# Altium schematic sheet CITest Board_v3.1_B.SchDoc
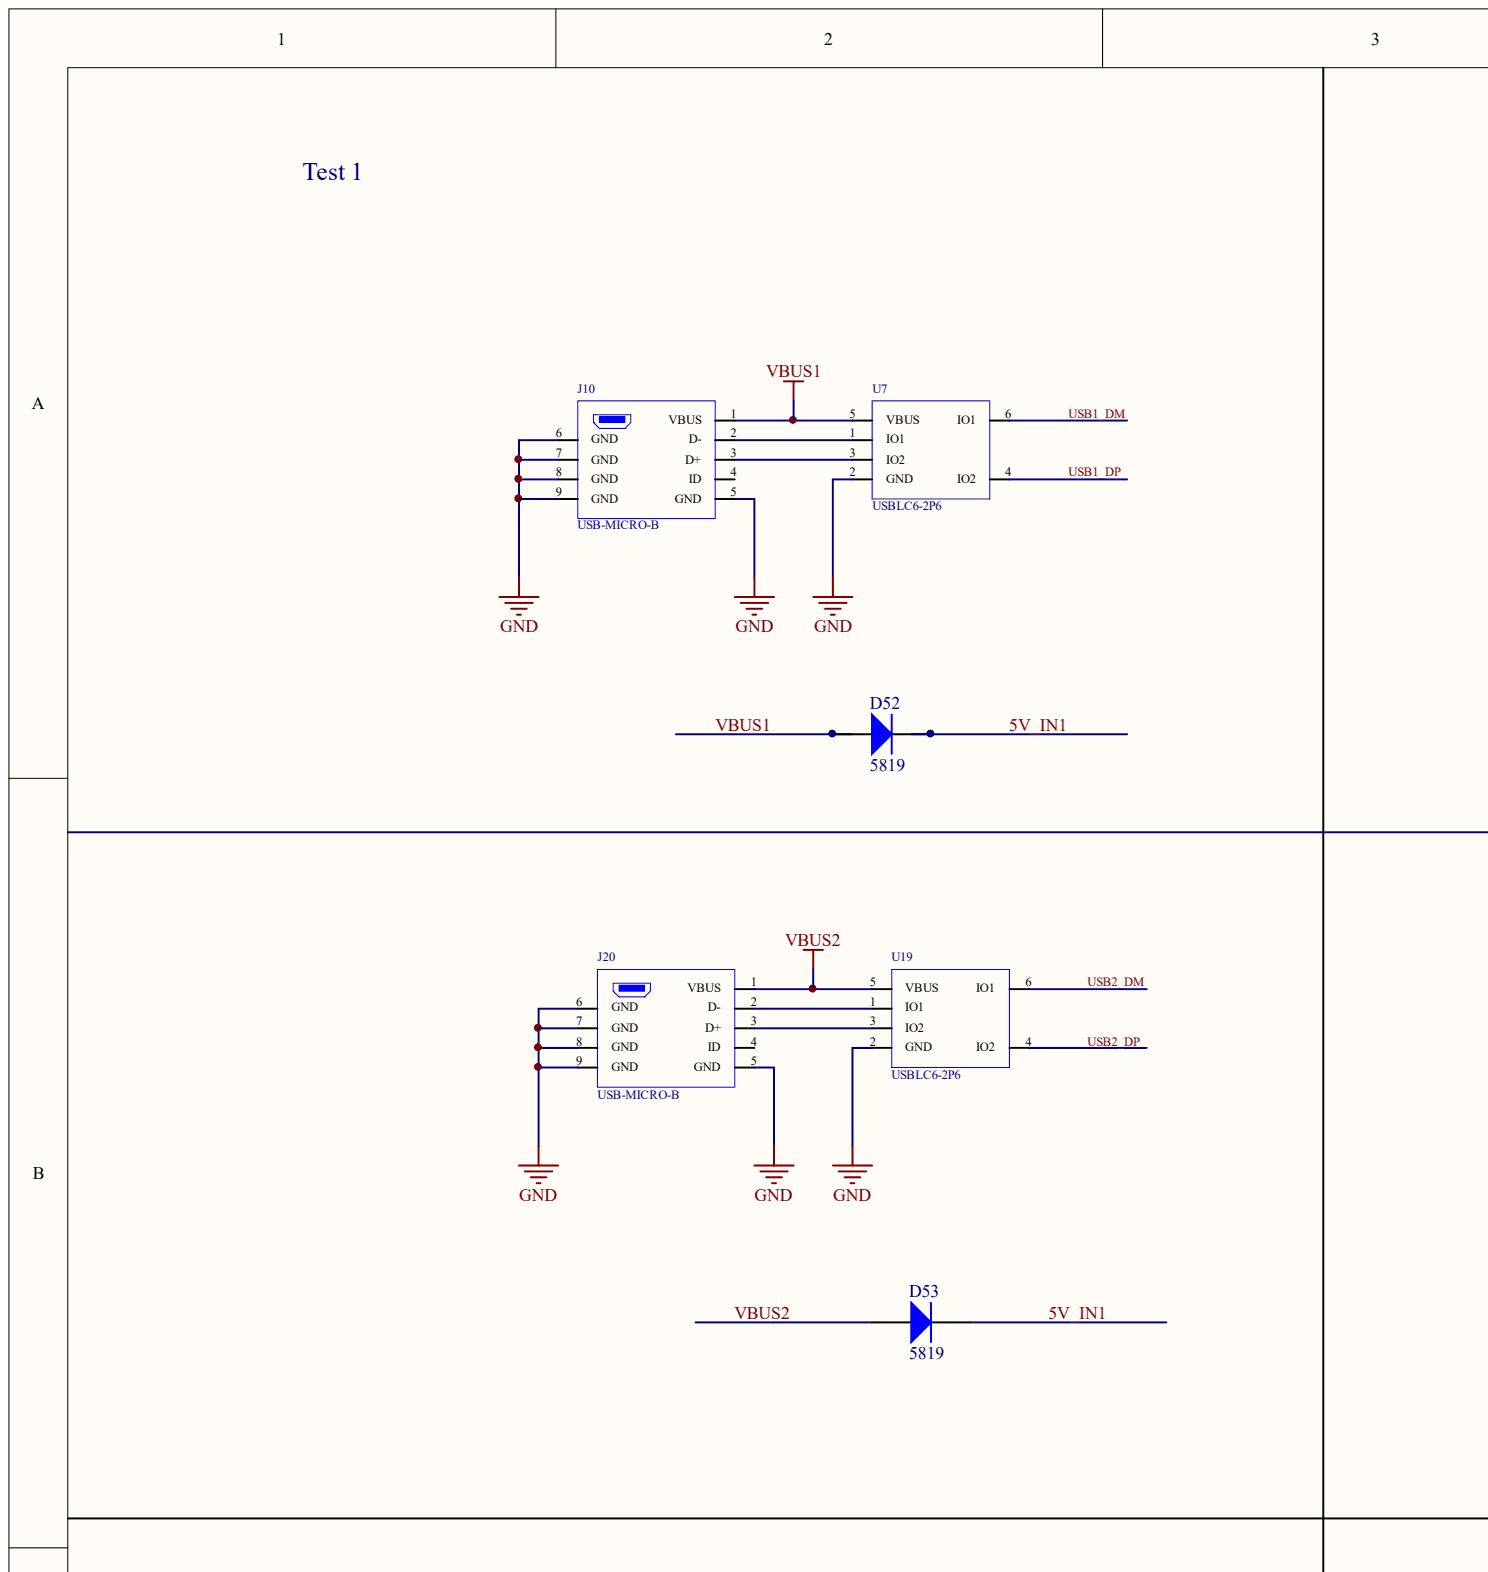
[diagram p0: CITest Board_v3.1_B.SchDoc, top left]
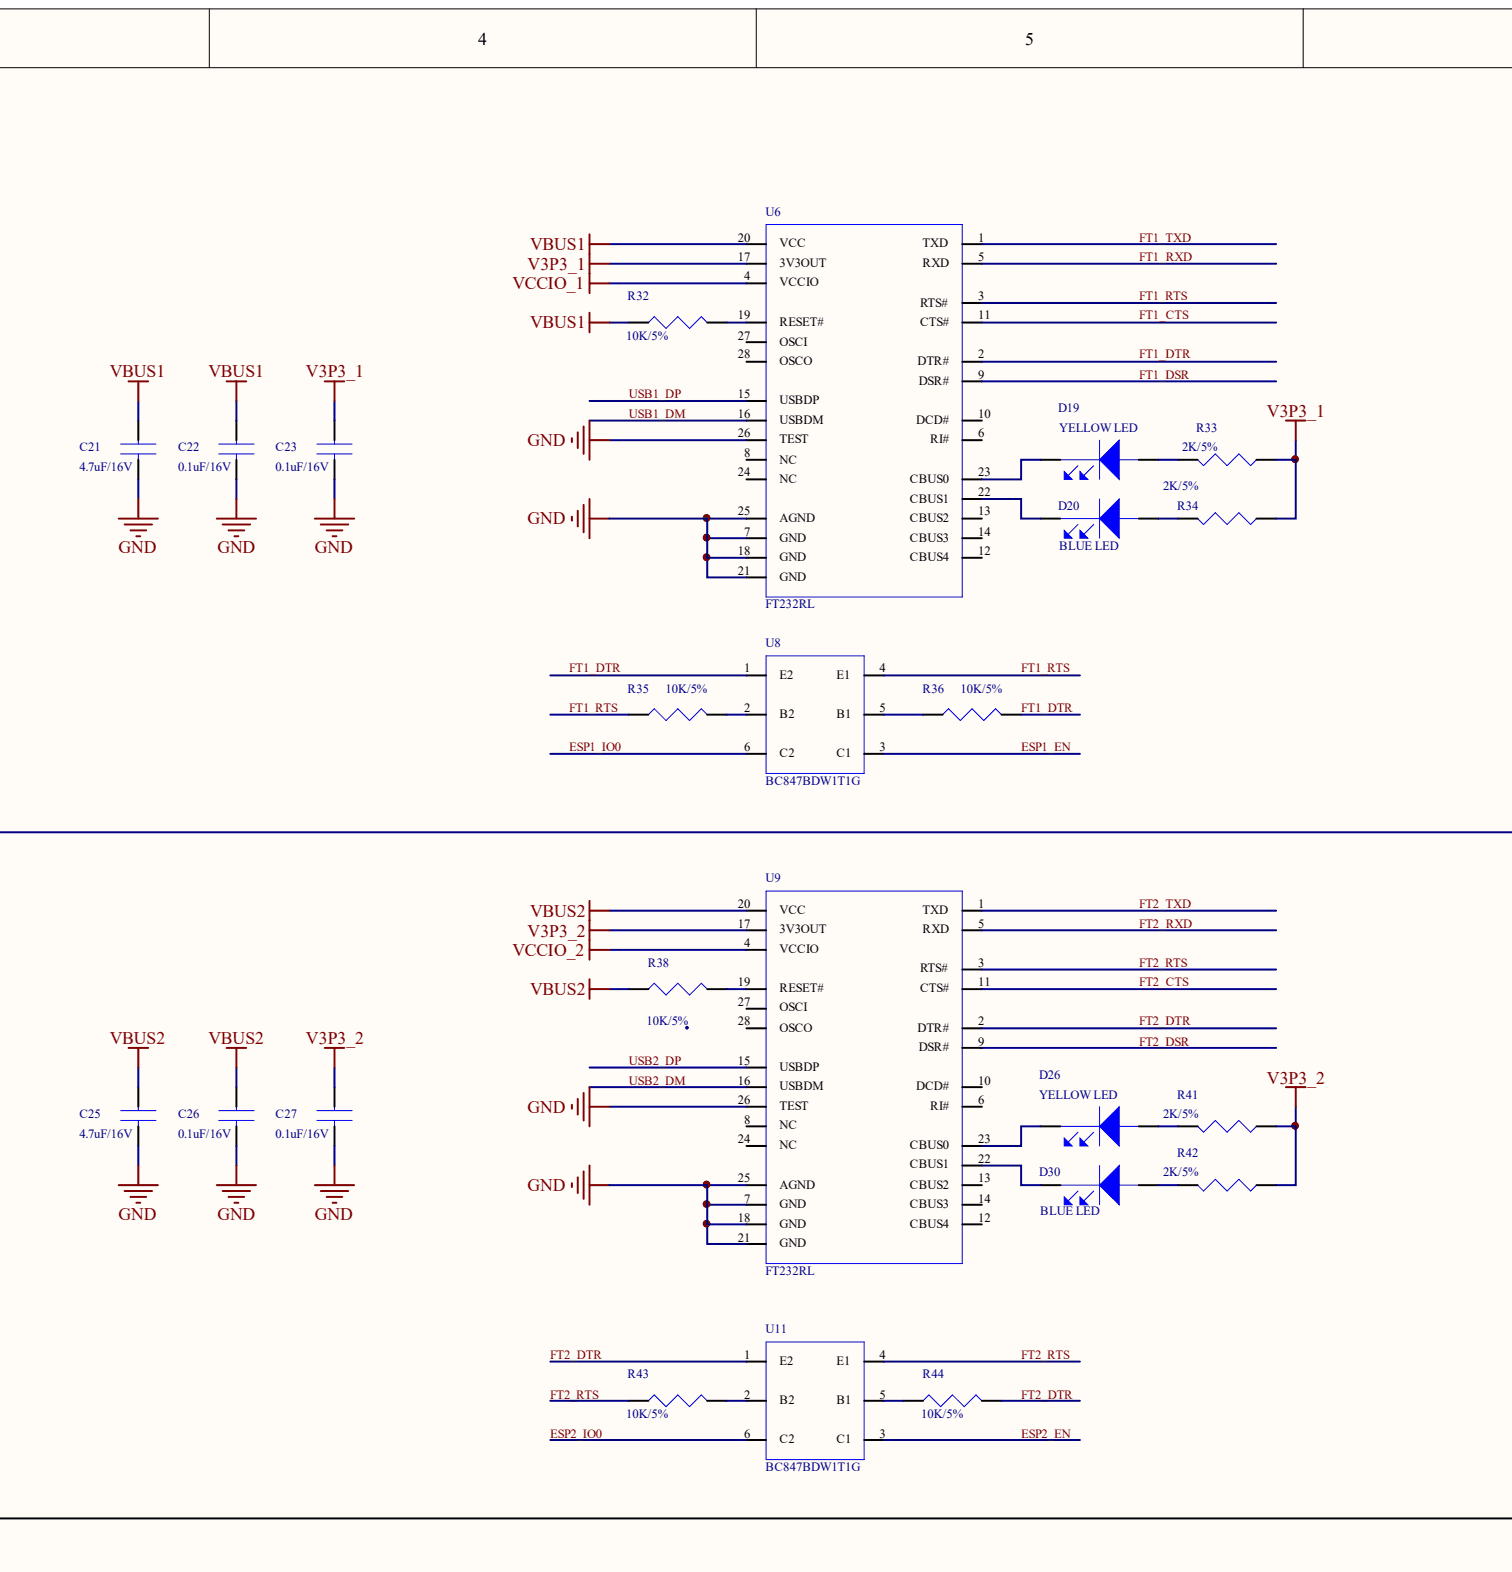
[diagram p1: CITest Board_v3.1_B.SchDoc, top centre]
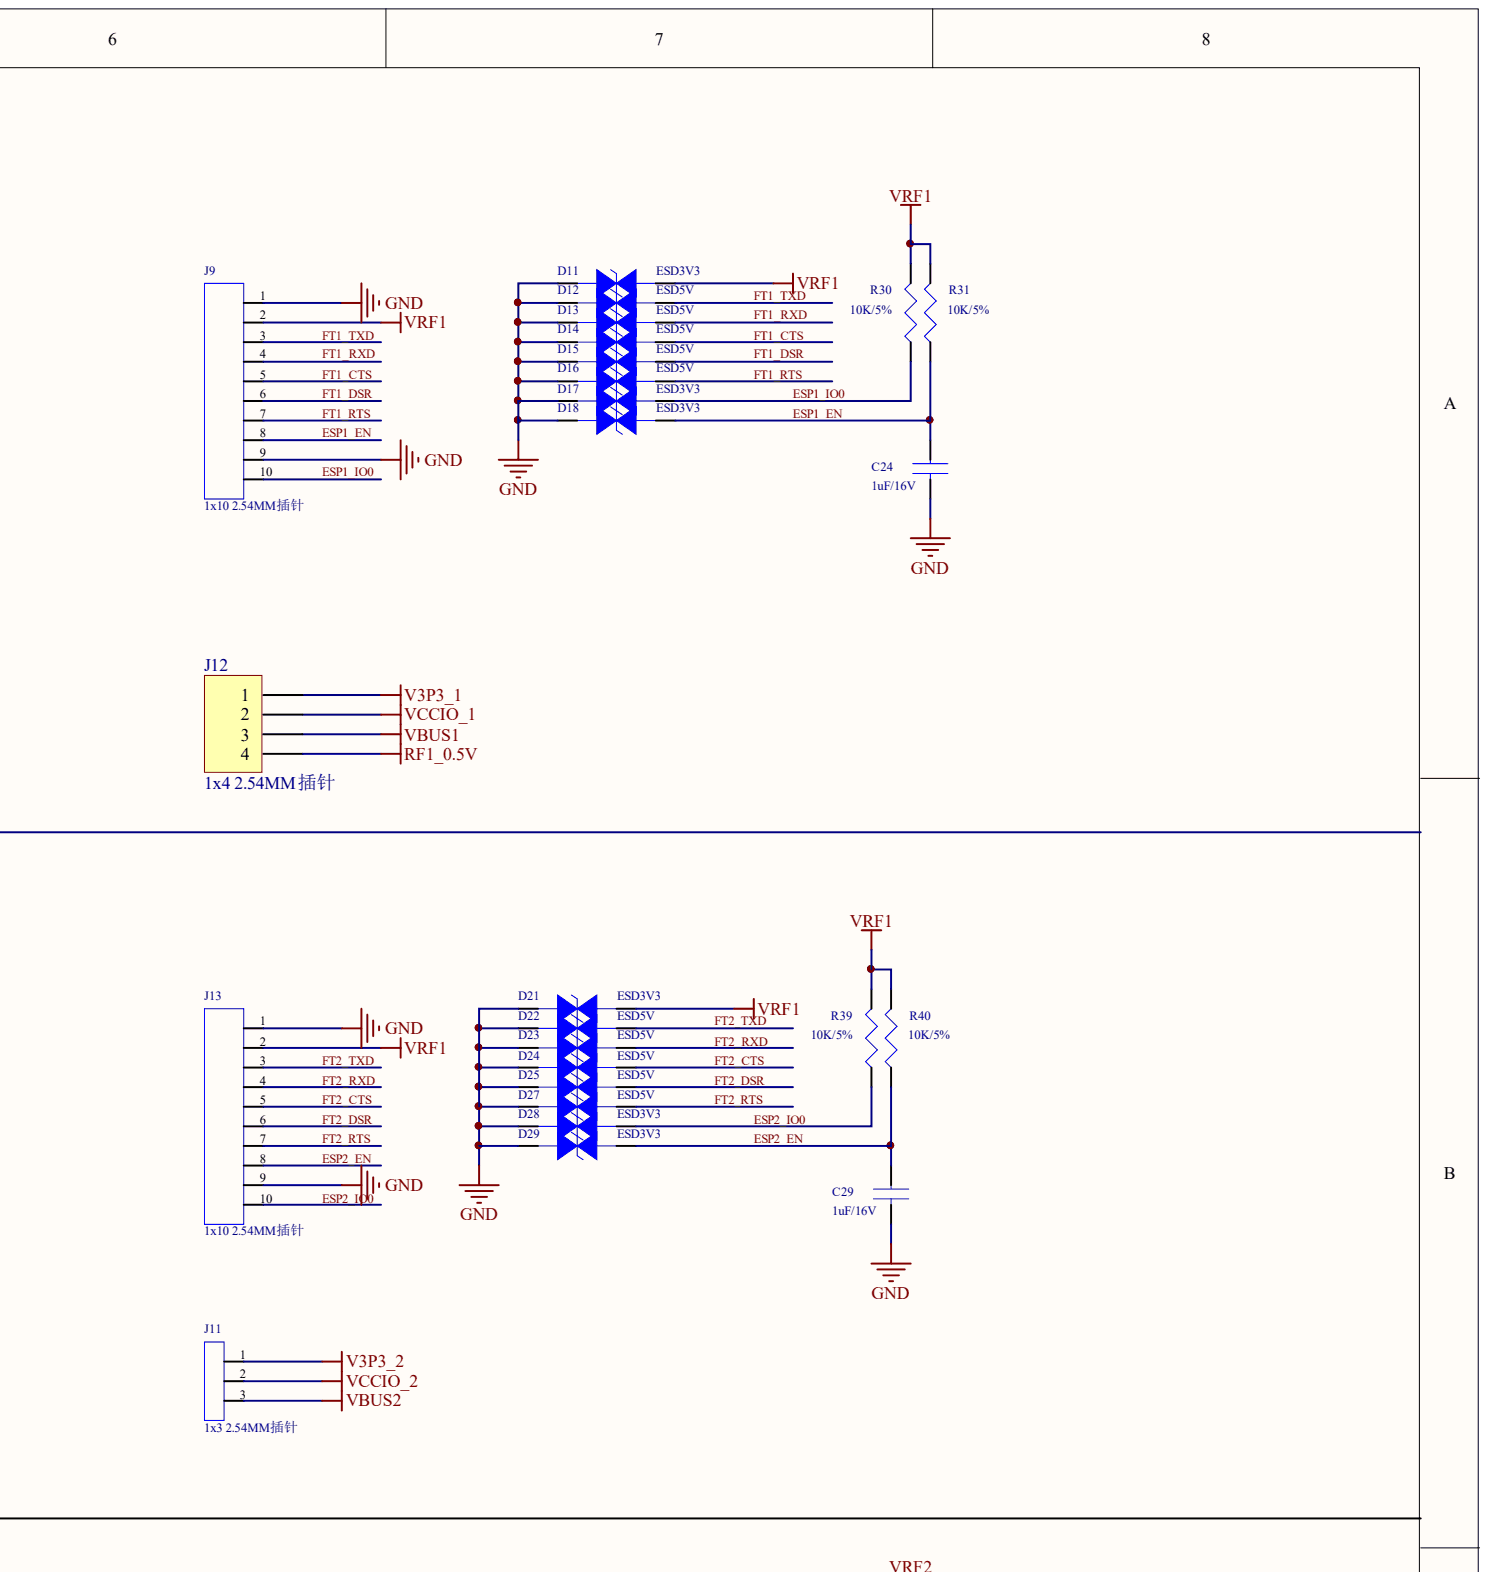
[diagram p2: CITest Board_v3.1_B.SchDoc, top right]
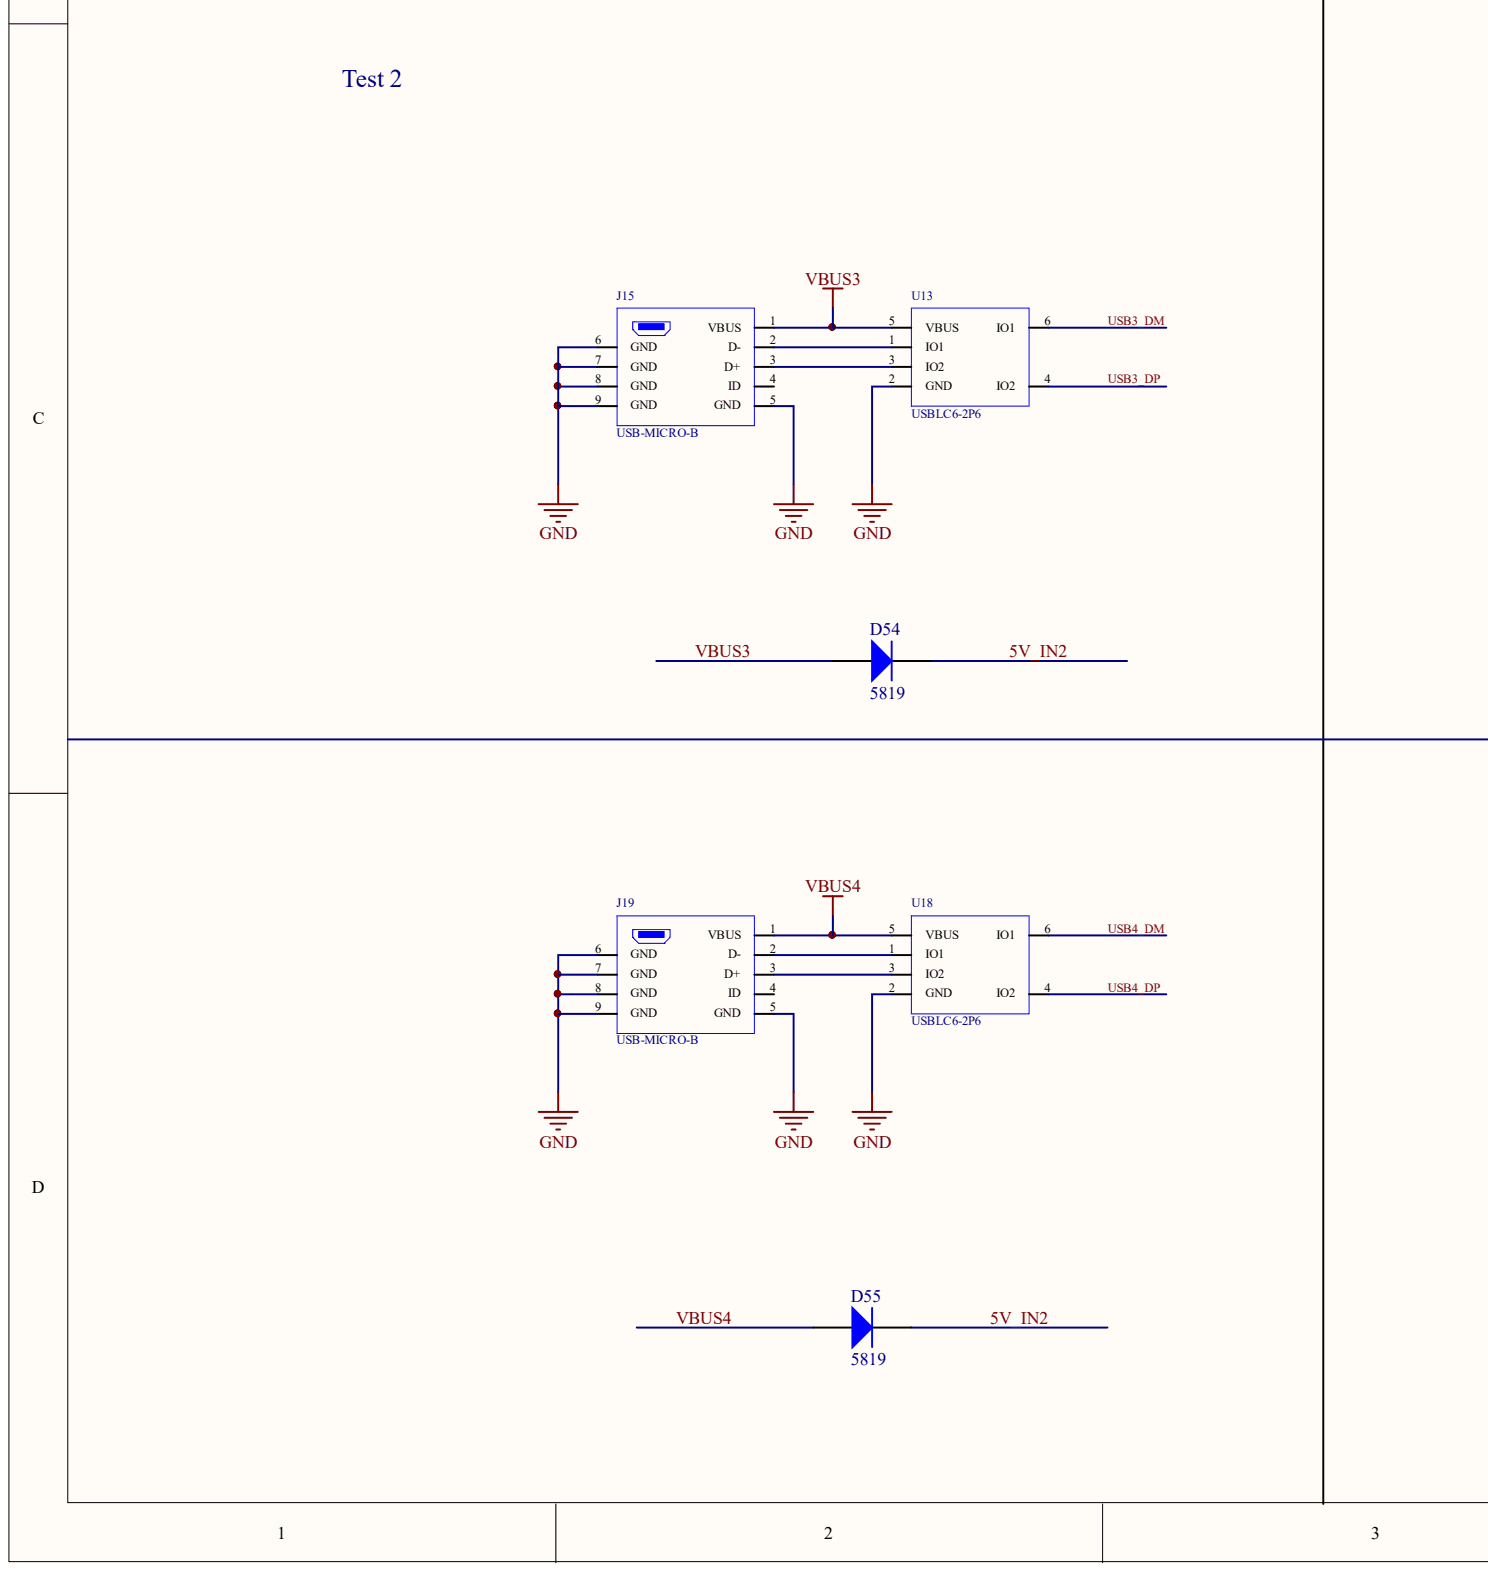
[diagram p3: CITest Board_v3.1_B.SchDoc, bottom left]
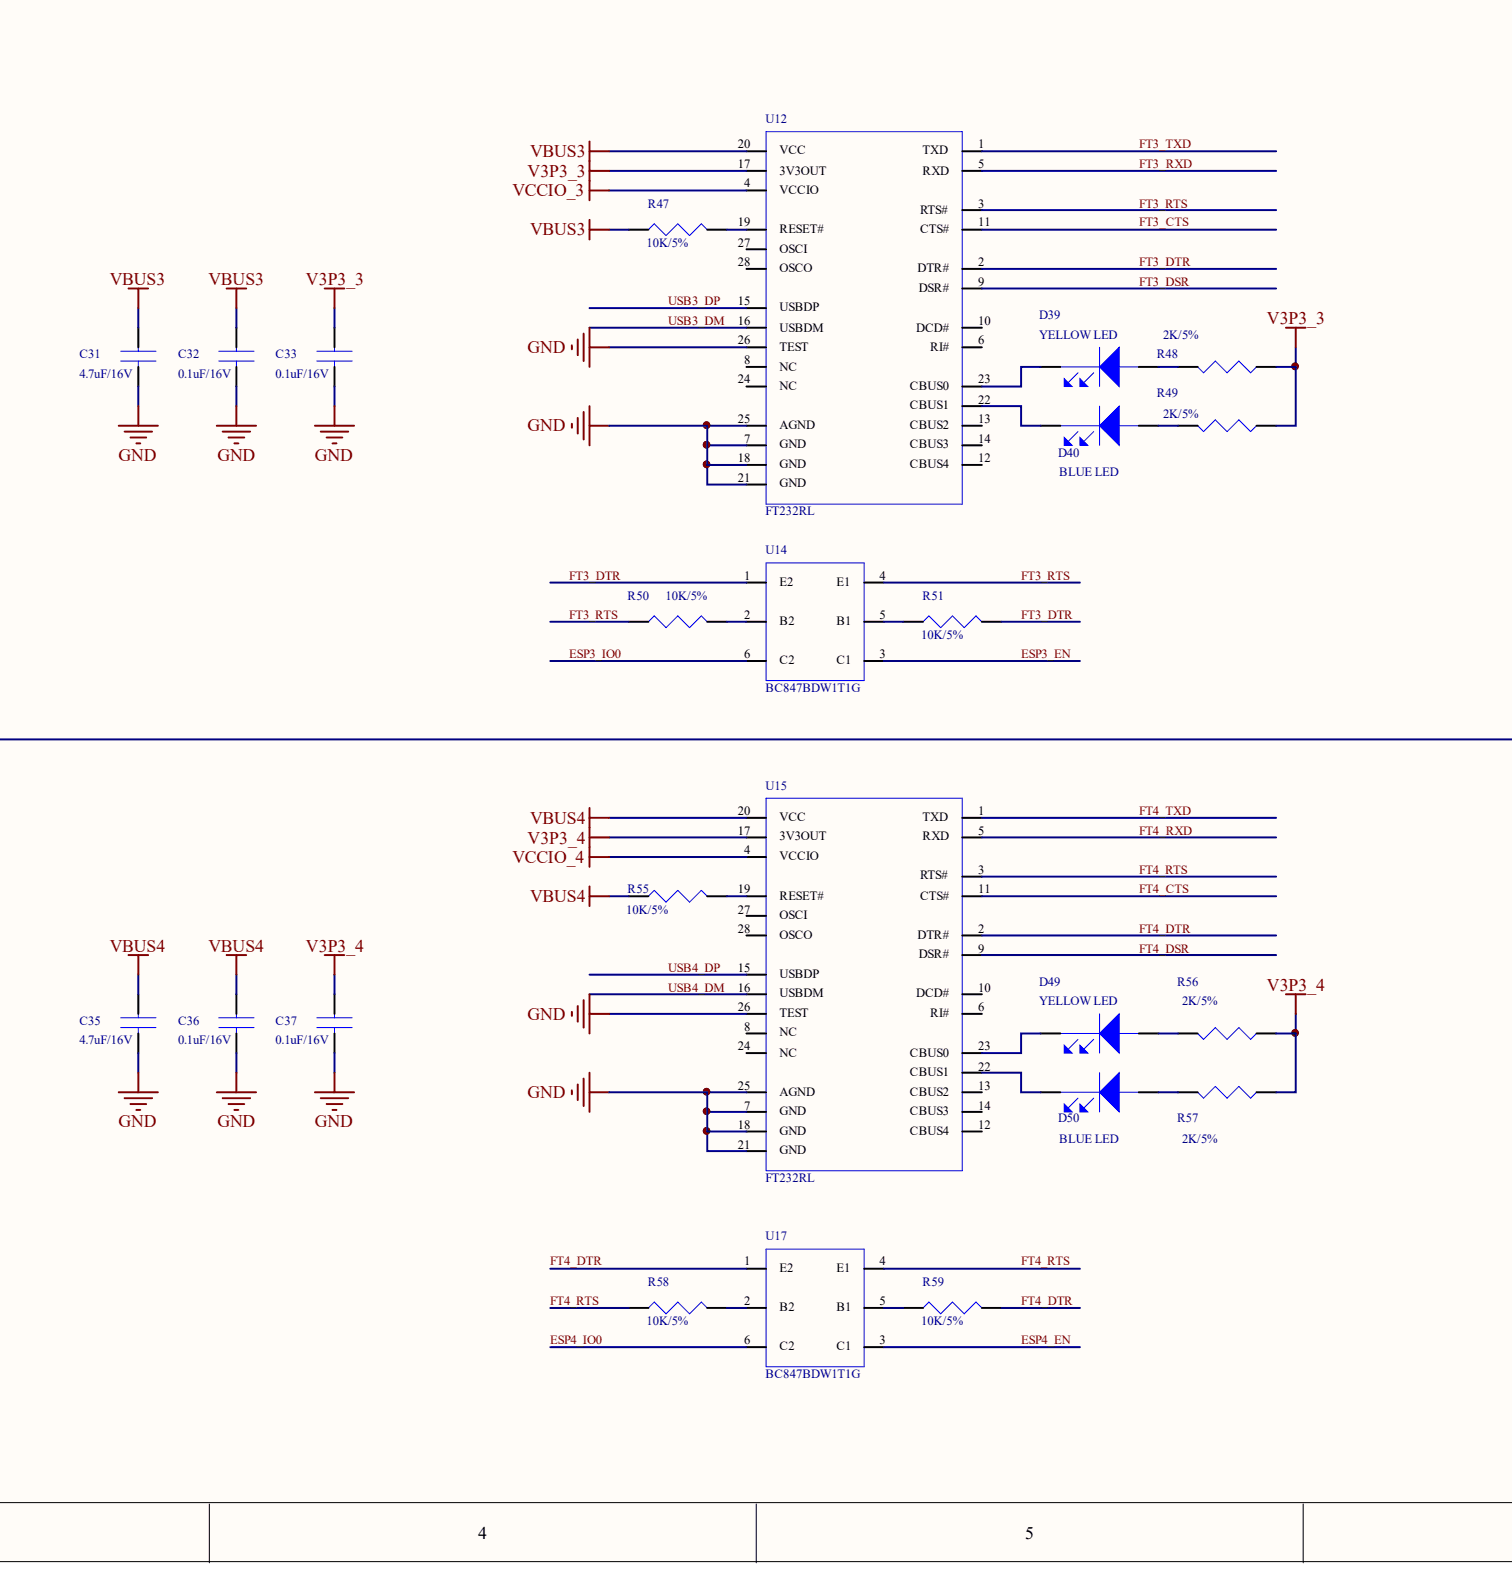
[diagram p4: CITest Board_v3.1_B.SchDoc, bottom centre]
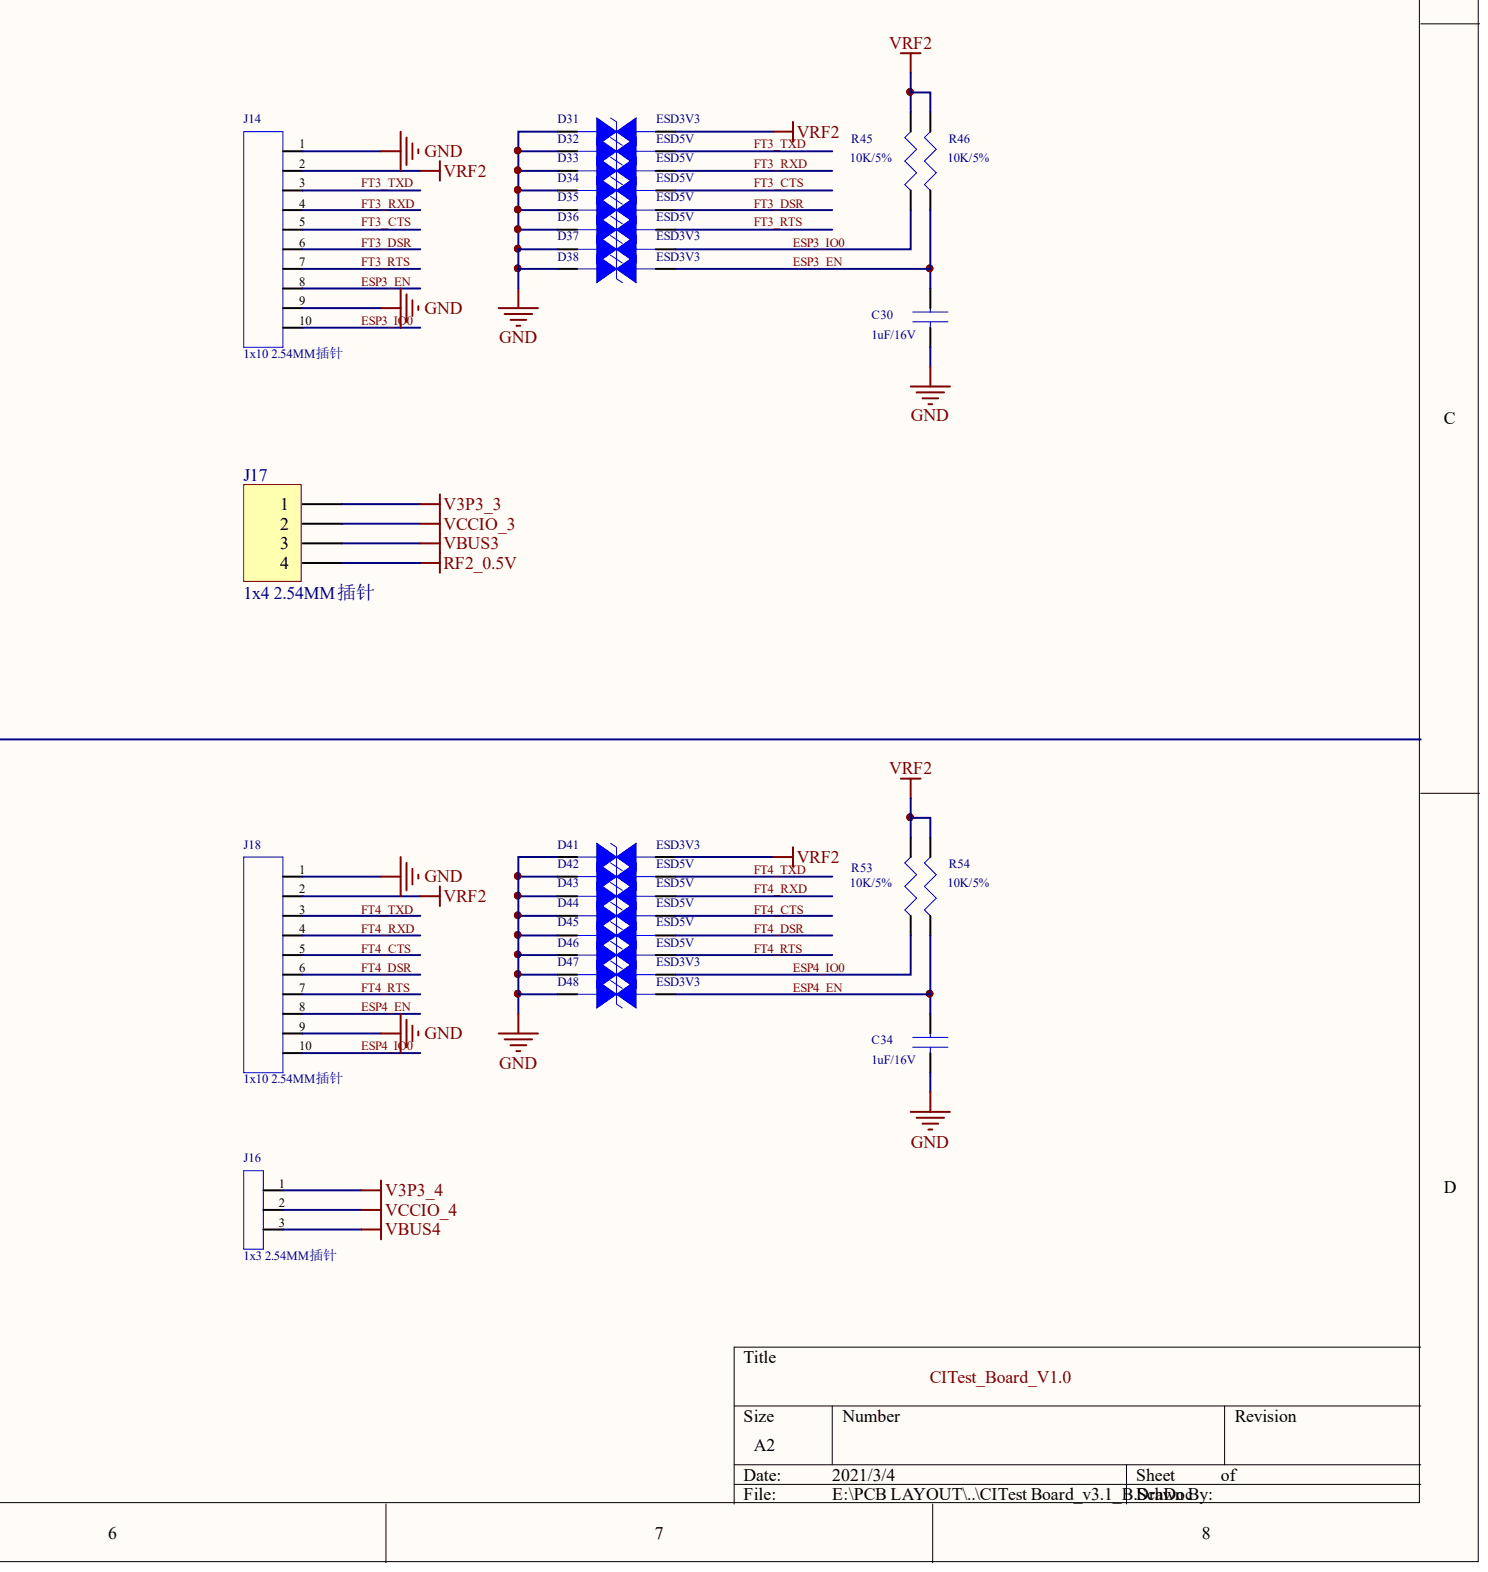
[diagram p5: CITest Board_v3.1_B.SchDoc, bottom right]

## Parts
C21  4.7uF/16V
C22  0.1uF/16V
C23  0.1uF/16V
C24  1uF/16V
C25  4.7uF/16V
C26  0.1uF/16V
C27  0.1uF/16V
C29  1uF/16V
C30  1uF/16V
C31  4.7uF/16V
C32  0.1uF/16V
C33  0.1uF/16V
C34  1uF/16V
C35  4.7uF/16V
C36  0.1uF/16V
C37  0.1uF/16V
D11  ESD3V3
D12  ESD5V
D13  ESD5V
D14  ESD5V
D15  ESD5V
D16  ESD5V
D17  ESD3V3
D18  ESD3V3
D19  YELLOW LED
D20  BLUE LED
D21  ESD3V3
D22  ESD5V
D23  ESD5V
D24  ESD5V
D25  ESD5V
D26  YELLOW LED
D27  ESD3V3
D28  ESD3V3
D29
D30  BLUE LED
D31  ESD3V3
D32  ESD5V
D33  ESD5V
D34  ESD5V
D35  ESD5V
D36  ESD3V3
D37  ESD3V3
D38  ESD5V
D39  YELLOW LED
D40  BLUE LED
D41  ESD5V
D42  ESD5V
D43  ESD3V3
D44  ESD5V
D45  ESD5V
D46  ESD3V3
D47  ESD3V3
D48
D49  YELLOW LED
D50  BLUE LED
D52  5819
D53  5819
D54  5819
D55  5819
J9  1x10 2.54MM插针
J10  USB-MICRO-B
J11  1x3 2.54MM插针
J12  1x4 2.54MM插针
J13  1x10 2.54MM插针
J14  1x10 2.54MM插针
J15  USB-MICRO-B
J16  1x3 2.54MM插针
J17  1x4 2.54MM插针
J18  1x10 2.54MM插针
J19  USB-MICRO-B
J20  USB-MICRO-B
R30  10K/5%
R31  10K/5%
R32  10K/5%
R33  2K/5%
R34  2K/5%
R35  10K/5%
R36  10K/5%
R38  10K/5%
R39  10K/5%
R40  10K/5%
R41  2K/5%
R42  2K/5%
R43  10K/5%
R44  10K/5%
R45  10K/5%
R46  10K/5%
R47  10K/5%
R48  2K/5%
R49  2K/5%
R50  10K/5%
R51  10K/5%
R53  10K/5%
R54  10K/5%
R55  10K/5%
R56  2K/5%
R57  2K/5%
R58  10K/5%
R59  10K/5%
U6  FT232RL
U7  USBLC6-2P6
U8  BC847BDW1T1G
U9  FT232RL
U11  BC847BDW1T1G
U12  FT232RL
U13  USBLC6-2P6
U14  BC847BDW1T1G
U15  FT232RL
U17  BC847BDW1T1G
U18  USBLC6-2P6
U19  USBLC6-2P6

## Nets
GND: C21.2 C22.2 C23.2 C24.2 C25.2 C26.2 C27.2 C29.2 C30.2 C31.2 C32.2 C33.2 C34.2 C35.2 C36.2 C37.2 D11.1 D12.1 D13.1 D14.1 D15.1 D16.1 D17.1 D18.1 D21.1 D22.1 D23.1 D24.1 D25.1 D27.1 D28.1 D29.1 D31.1 D32.1 D33.1 D34.1 D35.1 D36.1 D37.1 D38.1 D41.1 D42.1 D43.1 D44.1 D45.1 D46.1 D47.1 D48.1 J9.1 J9.9 J10.5(GND) J10.6(GND) J10.7(GND) J10.8(GND) J10.9(GND) J13.1 J13.9 J14.1 J14.9 J15.5(GND) J15.6(GND) J15.7(GND) J15.8(GND) J15.9(GND) J18.1 J18.9 J19.5(GND) J19.6(GND) J19.7(GND) J19.8(GND) J19.9(GND) J20.5(GND) J20.6(GND) J20.7(GND) J20.8(GND) J20.9(GND) U6.7(GND) U6.18(GND) U6.21(GND) U6.25(AGND) U6.26(TEST) U7.2(GND) U9.7(GND) U9.18(GND) U9.21(GND) U9.25(AGND) U9.26(TEST) U12.7(GND) U12.18(GND) U12.21(GND) U12.25(AGND) U12.26(TEST) U13.2(GND) U15.7(GND) U15.18(GND) U15.21(GND) U15.25(AGND) U15.26(TEST) U18.2(GND) U19.2(GND)
RF1_0.5V: J12.4
RF2_0.5V: J17.4
V3P3_1: C23.1 J12.1 R33.1 R34.1 U6.17(3V3OUT)
V3P3_2: C27.1 J11.1 R41.1 R42.1 U9.17(3V3OUT)
V3P3_3: C33.1 J17.1 R48.1 R49.1 U12.17(3V3OUT)
V3P3_4: C37.1 J16.1 R56.1 R57.1 U15.17(3V3OUT)
VCCIO_1: J12.2 U6.4(VCCIO)
VCCIO_2: J11.2 U9.4(VCCIO)
VCCIO_3: J17.2 U12.4(VCCIO)
VCCIO_4: J16.2 U15.4(VCCIO)
VRF1: D11.2 D21.2 J9.2 J13.2 R30.2 R31.2 R39.2 R40.2
VRF2: D31.2 D41.2 J14.2 J18.2 R45.2 R46.2 R53.2 R54.2
5V_IN1: D52.2 D53.2
5V_IN2: D54.2 D55.2
ESP1_EN: C24.1 D18.2 J9.8 R31.1 U8.3(C1)
ESP1_IO0: D17.2 J9.10 R30.1 U8.6(C2)
ESP2_EN: C29.1 D29.2 J13.8 R40.1 U11.3(C1)
ESP2_IO0: D28.2 J13.10 R39.1 U11.6(C2)
ESP3_EN: C30.1 D38.2 J14.8 R46.1 U14.3(C1)
ESP3_IO0: D37.2 J14.10 R45.1 U14.6(C2)
ESP4_EN: C34.1 D48.2 J18.8 R54.1 U17.3(C1)
ESP4_IO0: D47.2 J18.10 R53.1 U17.6(C2)
FT1_CTS: D14.2 J9.5 U6.11(CTS#)
FT1_DSR: D15.2 J9.6 U6.9(DSR#)
FT1_DTR: R36.2 U6.2(DTR#) U8.1(E2)
FT1_RTS: D16.2 J9.7 R35.1 U6.3(RTS#) U8.4(E1)
FT1_RXD: D13.2 J9.4 U6.5(RXD)
FT1_TXD: D12.2 J9.3 U6.1(TXD)
FT2_CTS: D24.2 J13.5 U9.11(CTS#)
FT2_DSR: D25.2 J13.6 U9.9(DSR#)
FT2_DTR: R44.2 U9.2(DTR#) U11.1(E2)
FT2_RTS: D27.2 J13.7 R43.1 U9.3(RTS#) U11.4(E1)
FT2_RXD: D23.2 J13.4 U9.5(RXD)
FT2_TXD: D22.2 J13.3 U9.1(TXD)
FT3_CTS: D34.2 J14.5 U12.11(CTS#)
FT3_DSR: D35.2 J14.6 U12.9(DSR#)
FT3_DTR: R51.2 U12.2(DTR#) U14.1(E2)
FT3_RTS: D36.2 J14.7 R50.1 U12.3(RTS#) U14.4(E1)
FT3_RXD: D33.2 J14.4 U12.5(RXD)
FT3_TXD: D32.2 J14.3 U12.1(TXD)
FT4_CTS: D44.2 J18.5 U15.11(CTS#)
FT4_DSR: D45.2 J18.6 U15.9(DSR#)
FT4_DTR: R59.2 U15.2(DTR#) U17.1(E2)
FT4_RTS: D46.2 J18.7 R58.1 U15.3(RTS#) U17.4(E1)
FT4_RXD: D43.2 J18.4 U15.5(RXD)
FT4_TXD: D42.2 J18.3 U15.1(TXD)
USB1_DM: U6.16(USBDM) U7.6(IO1)
USB1_DP: U6.15(USBDP) U7.4(IO2)
USB2_DM: U9.16(USBDM) U19.6(IO1)
USB2_DP: U9.15(USBDP) U19.4(IO2)
USB3_DM: U12.16(USBDM) U13.6(IO1)
USB3_DP: U12.15(USBDP) U13.4(IO2)
USB4_DM: U15.16(USBDM) U18.6(IO1)
USB4_DP: U15.15(USBDP) U18.4(IO2)
VBUS1: C21.1 C22.1 D52.1 J10.1(VBUS) J12.3 R32.1 U6.20(VCC) U7.5(VBUS)
VBUS2: C25.1 C26.1 D53.1 J11.3 J20.1(VBUS) R38.1 U9.20(VCC) U19.5(VBUS)
VBUS3: C31.1 C32.1 D54.1 J15.1(VBUS) J17.3 R47.1 U12.20(VCC) U13.5(VBUS)
VBUS4: C35.1 C36.1 D55.1 J16.3 J19.1(VBUS) R55.1 U15.20(VCC) U18.5(VBUS)
NetD19_1: D19.1 R33.2
NetD19_2: D19.2 U6.23(CBUS0)
NetD20_1: D20.1 R34.2
NetD20_2: D20.2 U6.22(CBUS1)
NetD26_1: D26.1 R41.2
NetD26_2: D26.2 U9.23(CBUS0)
NetD30_1: D30.1 R42.2
NetD30_2: D30.2 U9.22(CBUS1)
NetD39_1: D39.1 R48.2
NetD39_2: D39.2 U12.23(CBUS0)
NetD40_1: D40.1 R49.2
NetD40_2: D40.2 U12.22(CBUS1)
NetD49_1: D49.1 R56.2
NetD49_2: D49.2 U15.23(CBUS0)
NetD50_1: D50.1 R57.2
NetD50_2: D50.2 U15.22(CBUS1)
NetJ10_2: J10.2(D-) U7.1(IO1)
NetJ10_3: J10.3(D+) U7.3(IO2)
NetJ15_2: J15.2(D-) U13.1(IO1)
NetJ15_3: J15.3(D+) U13.3(IO2)
NetJ19_2: J19.2(D-) U18.1(IO1)
NetJ19_3: J19.3(D+) U18.3(IO2)
NetJ20_2: J20.2(D-) U19.1(IO1)
NetJ20_3: J20.3(D+) U19.3(IO2)
NetR32_2: R32.2 U6.19(RESET#)
NetR35_2: R35.2 U8.2(B2)
NetR36_1: R36.1 U8.5(B1)
NetR38_2: R38.2 U9.19(RESET#)
NetR43_2: R43.2 U11.2(B2)
NetR44_1: R44.1 U11.5(B1)
NetR47_2: R47.2 U12.19(RESET#)
NetR50_2: R50.2 U14.2(B2)
NetR51_1: R51.1 U14.5(B1)
NetR55_2: R55.2 U15.19(RESET#)
NetR58_2: R58.2 U17.2(B2)
NetR59_1: R59.1 U17.5(B1)
CITEST_BOARD_V1.0:
N00129:
N00130:

Not connected: J10 4(ID); J15 4(ID); J19 4(ID); J20 4(ID); U6 6(RI#) 8(NC) 10(DCD#) 12(CBUS4) 13(CBUS2) 14(CBUS3) 24(NC) 27(OSCI) 28(OSCO); U9 6(RI#) 8(NC) 10(DCD#) 12(CBUS4) 13(CBUS2) 14(CBUS3) 24(NC) 27(OSCI) 28(OSCO); U12 6(RI#) 8(NC) 10(DCD#) 12(CBUS4) 13(CBUS2) 14(CBUS3) 24(NC) 27(OSCI) 28(OSCO); U15 6(RI#) 8(NC) 10(DCD#) 12(CBUS4) 13(CBUS2) 14(CBUS3) 24(NC) 27(OSCI) 28(OSCO)

## Library data of the parts
C21 C25 C31 C35 = (no symbol name): PCB DECAL: C0603; Source_Path: C:\ORCAD\ORCAD_10.5\TOOLS\CAPTURE\LIBRARY\DISCRETE.OLB; Value: 4.7uF/16V
C22-C24 C26 C27 C29 C30 C32-C34 C36 C37 = (no symbol name): PCB DECAL: C0402; Source_Path: C:\ORCAD\ORCAD_10.5\TOOLS\CAPTURE\LIBRARY\DISCRETE.OLB
  C22 C23 C26 C27 C32 C33 C36 C37: Value: 0.1uF/16V
  C24 C29 C30 C34: Value: 1uF/16V
D11-D18 D21-D25 D27 D28 D31-D38 D41-D47 = (no symbol name): PCB DECAL: SOD-523; Source_Path: E:\PROJECT_NOW\ALMID03_LIBRARY.OLB
  D11 D17 D18 D21 D27 D28 D31 D36 D37 D43 D46 D47: Value: ESD3V3
  D12-D16 D22-D25 D32-D35 D38 D41 D42 D44 D45: Value: ESD5V
D19 D20 D26 D30 D39 D40 D49 D50 = (no symbol name): PCB DECAL: LED0603; Source_Path: D:\PROJECT\ESPRESSIF_PROJECT\#10444_ESP_FACTORY TEST BOARD FOR MODULE_V1.4_N_XX_20190408_V0.4\01_SCHEMATIC\ESP_FACTORY TEST BOARD FOR MODULE_V1.4_20190408.DSN
  D19 D26 D39 D49: Value: YELLOW LED
  D20 D30 D40 D50: Value: BLUE LED
J9 J13 J14 J18 = (no symbol name): PCB DECAL: CON10-XH-2P54; Source_Path: D:\YUXUFENG\ESPPROJECT\#30258-FACTORY_TESTBOARD\#30258-FACTORY_TESTBOARD_190910\01_SCH\ESP_FACTORY_TESTBOARD.DSN; Value: 1x10 2.54MM插针
J10 J15 J19 J20 = (no symbol name): PCB DECAL: USB-5P-MICROB; Source_Path: D:\YUXUFENG\ESPPROJECT\#30258-FACTORY_TESTBOARD\01_SCH\ESP_FACTORY_TESTBOARD.DSN; Value: USB-MICRO-B
J11 J16 = (no symbol name): PCB DECAL: CON3-2P54; Source_Path: D:\YUXUFENG\ESPPROJECT\#30258-FACTORY_TESTBOARD\01_SCH\ESP_FACTORY_TESTBOARD.DSN; Value: 1x3 2.54MM插针
R30-R36 R38-R51 R53-R59 = (no symbol name): PCB DECAL: R0402; Source_Path: E:\LI LELE\PROJ_LLL\NEW F BOARD\ESP8266 EVALUATION BOARD\ESP8266 EVALUATION BOARD.DSN
  R30-R32 R35 R36 R38-R40 R43-R47 R50 R51 R53-R55 R58 R59: Value: 10K/5%
  R33 R34 R41 R42 R48 R49 R56 R57: Value: 2K/5%
U6 U9 U12 U15 = (no symbol name): PCB DECAL: SSOP28-5P3; Source_Path: D:\YUXUFENG\ESPPROJECT\#30258-FACTORY_TESTBOARD\01_SCH\ESP_FACTORY_TESTBOARD.DSN; Value: FT232RL
U7 U13 U18 U19 = (no symbol name): PCB DECAL: SOT-666; Source_Path: D:\YUXUFENG\ESPPROJECT\#30258-FACTORY_TESTBOARD\01_SCH\ESP_FACTORY_TESTBOARD.DSN; Value: USBLC6-2P6
U8 U11 U14 U17 = (no symbol name): PCB DECAL: SOT-363; Source_Path: D:\YUXUFENG\ESPPROJECT\#30258-FACTORY_TESTBOARD\01_SCH\ESP_FACTORY_TESTBOARD.DSN; Value: BC847BDW1T1G
D52-D55 J12 J17 = (no symbol name)
  D52-D55: ComponentLink1Description: 供应商链接; ComponentLink1URL: http://www.szlcsc.com/product/details_2485.html; Package: SOD-323; Supplier: LC; SuppliersPartNumber: C2128
  J12 J17: LatestRevisionDate: 17-Jul-2002; LatestRevisionNote: Re-released for DXP Platform.; Publisher: Altium Limited
